# Altium schematic sheet StEth32_Base_µC.SchDoc
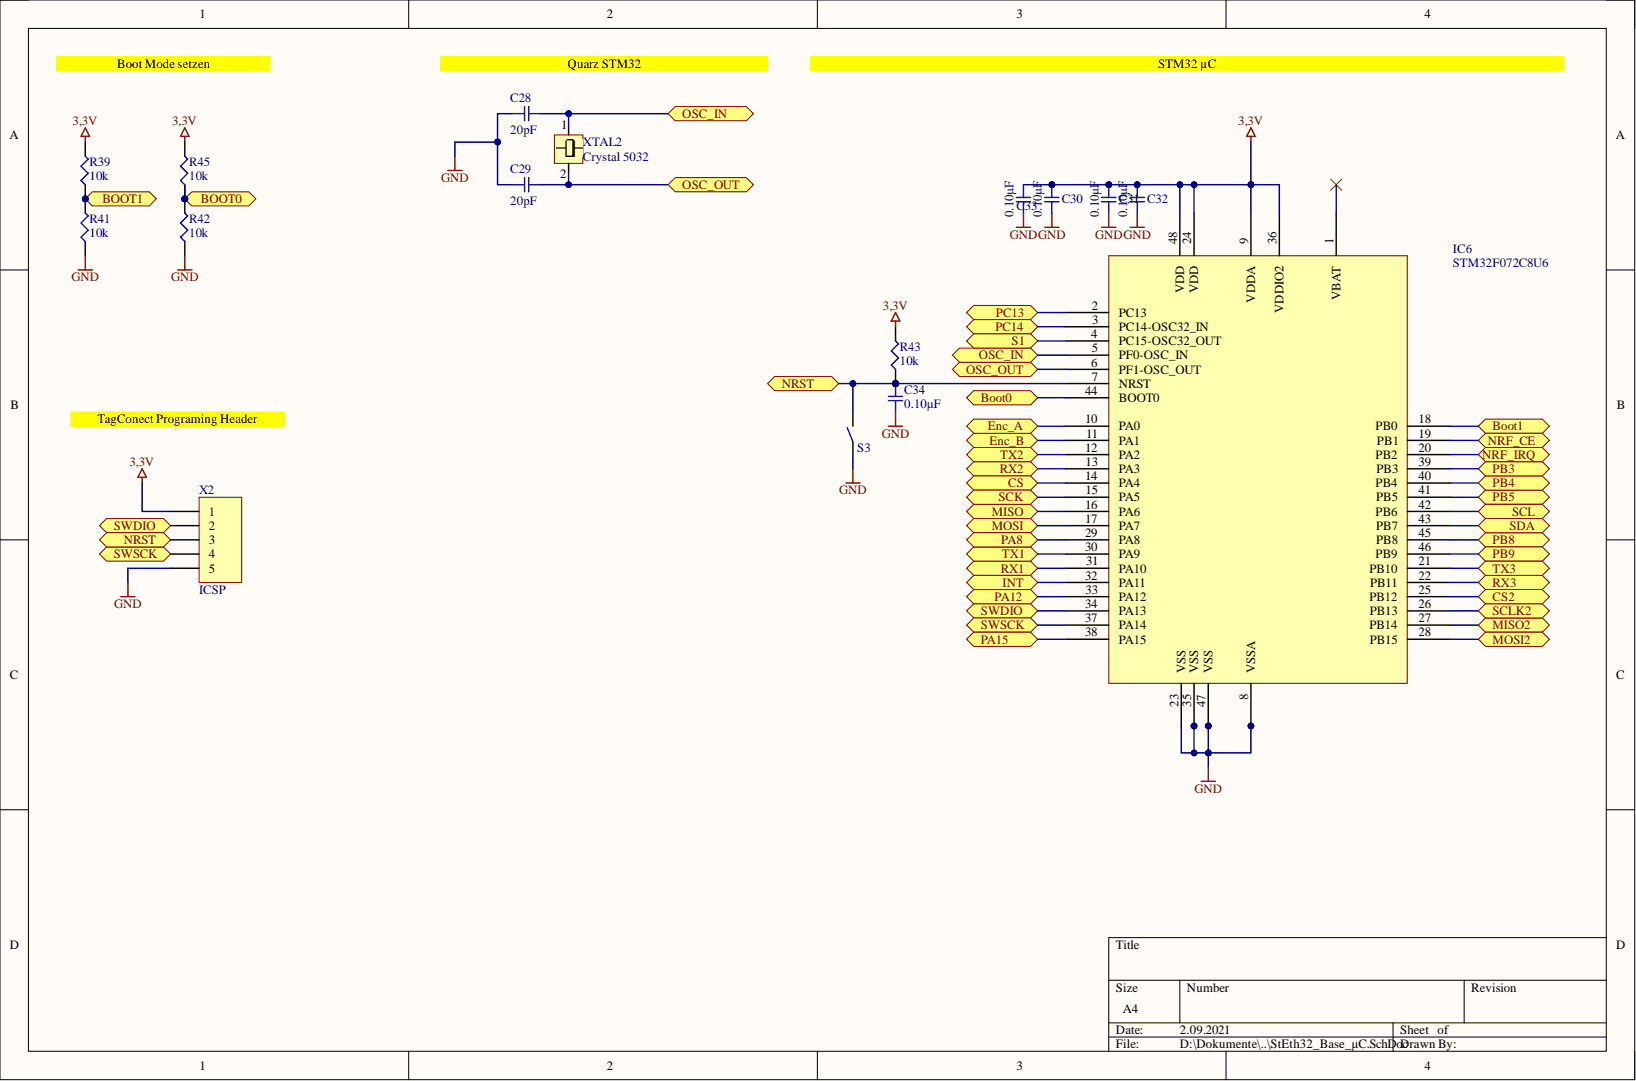
[diagram p0: StEth32_Base_µC.SchDoc]

## Parts
C28  20pF  [SMD-0603C]
C29  20pF  [SMD-0603C]
C30  0.10µF  [SMD-0603C]
C31  0.10µF  [SMD-0603C]
C32  0.10µF  [SMD-0603C]
C33  0.10µF  [SMD-0603C]
C34  0.10µF  [SMD-0603C]
IC6  STM32F072C8U6  [LQFP-48]
R39  10k  [SMD-0603-RES]
R41  10k  [SMD-0603-RES]
R42  10k  [SMD-0603-RES]
R43  10k  [SMD-0603-RES]
R45  10k  [SMD-0603-RES]
S3  Button  [Button5050]  (value not drawn)
X2  ICSP  [TC2030-MCP-NL]
XTAL2  Crystal 5032  [5032]

## Ports
BOOT0, Boot0, Boot1, BOOT1, CS, CS2, Enc_A, Enc_B, INT, MISO, MISO2, MOSI, MOSI2, NRF_CE, NRF_IRQ, NRST, OSC_IN, OSC_OUT, PA8, PA12, PA15, PB3, PB4, PB5, PB8, PB9, PC13, PC14, RX1, RX2, RX3, S1, SCK, SCL, SCLK2, SDA, SWDIO, SWSCK, TX1, TX2, TX3

## Nets
3,3V: C30.1 C31.1 C32.1 C33.1 IC6.9(VDDA) IC6.24(VDD) IC6.36(VDDIO2) IC6.48(VDD) R39.2 R43.2 R45.2 X2.1
GND: C28.1 C29.1 C30.2 C31.2 C32.2 C33.2 C34.2 IC6.8(VSSA) IC6.23(VSS) IC6.35(VSS) IC6.47(VSS) R41.1 R42.1 S3.1 X2.5
BOOT0: IC6.44(BOOT0) R42.2 R45.1 ; port BOOT0, port Boot0
BOOT1: IC6.18(PB0) R39.1 R41.2 ; port BOOT1, port Boot1
CS: IC6.14(PA4) ; port CS
CS2: IC6.25(PB12) ; port CS2
Enc_A: IC6.10(PA0) ; port Enc_A
Enc_B: IC6.11(PA1) ; port Enc_B
INT: IC6.32(PA11) ; port INT
MISO: IC6.16(PA6) ; port MISO
MISO2: IC6.27(PB14) ; port MISO2
MOSI: IC6.17(PA7) ; port MOSI
MOSI2: IC6.28(PB15) ; port MOSI2
NRF_CE: IC6.19(PB1) ; port NRF_CE
NRF_IRQ: IC6.20(PB2) ; port NRF_IRQ
NRST: C34.1 IC6.7(NRST) R43.1 S3.2 X2.3 ; port NRST
OSC_IN: C28.2 IC6.5(PF0-OSC_IN) XTAL2.1 ; port OSC_IN
OSC_OUT: C29.2 IC6.6(PF1-OSC_OUT) XTAL2.2 ; port OSC_OUT
PA8: IC6.29(PA8) ; port PA8
PA12: IC6.33(PA12) ; port PA12
PA15: IC6.38(PA15) ; port PA15
PB3: IC6.39(PB3) ; port PB3
PB4: IC6.40(PB4) ; port PB4
PB5: IC6.41(PB5) ; port PB5
PB8: IC6.45(PB8) ; port PB8
PB9: IC6.46(PB9) ; port PB9
PC13: IC6.2(PC13) ; port PC13
PC14: IC6.3(PC14-OSC32_IN) ; port PC14
RX1: IC6.31(PA10) ; port RX1
RX2: IC6.13(PA3) ; port RX2
RX3: IC6.22(PB11) ; port RX3
S1: IC6.4(PC15-OSC32_OUT) ; port S1
SCK: IC6.15(PA5) ; port SCK
SCL: IC6.42(PB6) ; port SCL
SCLK2: IC6.26(PB13) ; port SCLK2
SDA: IC6.43(PB7) ; port SDA
SWDIO: IC6.34(PA13) X2.2 ; port SWDIO
SWSCK: IC6.37(PA14) X2.4 ; port SWSCK
TX1: IC6.30(PA9) ; port TX1
TX2: IC6.12(PA2) ; port TX2
TX3: IC6.21(PB10) ; port TX3

Not connected: IC6 1(VBAT)

## Library data of the parts
C28-C34 = GCM188R71C104KA37J: CAP CER 0.1UF 16V X7R 0603; Manufacturer: Murata Electronics North America; @ qty: 0; Minimum Quantity: 1; Tolerance: ±10%; Unit Price (USD): 0.18; Applications: Automotive; Component Kind: Standard; Datasheets: http://www.murata.com/~/media/webrenewal/support/library/catalog/products/capacitor/mlcc/c03e.ashx; Digi-Key Part Number: 490-8015-1-ND; Factory Stock: 0; Features: -; Height - Seated (Max): -; Image: //media.digikey.com/Renders/Murata%20Renders/0603%28GCM188%29.jpg; Lead Spacing: -; Lead Style: -; Library Name: capacitor_murata_0603.IntLib; Mounting Type: Surface Mount, MLCC; Operating Temperature: -55°C ~ 125°C; Package / Case: 0603 (1608 Metric); Packaging: Cut Tape (CT); Part Number: GCM188R71C104KA37J; Part Status: Active; Quantity Available: 17844; Ratings: AEC-Q200; Series: GCM; Size / Dimension: 0.063" L x 0.031" W (1.60mm x 0.80mm); Temperature Coefficient: X7R; Thickness (Max): 0.035" (0.90mm); Voltage: 16V
  C28 C29: Value: 20pF
  C30-C34: Value: 0.10µF
R39 R41-R43 R45 = ERJ-3EKF1002V: RES SMD 10K OHM 1% 1/10W 0603; Manufacturer: Panasonic Electronic Components; @ qty: 1500; Factory Stock: 0; Minimum Quantity: 1; Power (Watts): 0.1W, 1/10W; Temperature Coefficient: ±100ppm/°C; Unit Price (USD): 0.00379; Component Kind: Standard; Composition: Thick Film; Datasheets: http://industrial.panasonic.com/www-cgi/jvcr13pz.cgi?E+PZ+3+AOA0002+ERJ3EKF1002V+7+WW; Digi-Key Part Number: P10.0KHCT-ND; Failure Rate: -; Features: Automotive AEC-Q200; Height - Seated (Max): 0.022" (0.55mm); Image: //media.digikey.com/Photos/Panasonic%20Photos/MFG_ERJ-P6W%20Series.jpg; Library Name: Panasonic_ERJ_0603.IntLib; Number of Terminations: 2; Operating Temperature: -55°C ~ 155°C; Package / Case: 0603 (1608 Metric); Packaging: Cut Tape (CT); Part Number: ERJ-3EKF1002V; Part Status: Active; Quantity Available: 6703141; Series: ERJ; Size / Dimension: 0.063" L x 0.031" W (1.60mm x 0.80mm); Supplier Device Package: 0603; Tolerance: ±1%; Value: 10k
S3 = Button: 6.1x3.7x2.5mm; Component Kind: Standard; Library Name: MyLibs.SchLib
XTAL2 = 5032: Component Kind: Standard; Library Name: MyLibs.SchLib
IC6 = STM32F072C8U6: Component Kind: Standard; Library Name: MyLibs.SchLib
X2 = ICSP: Component Kind: Standard; Library Name: TC2030.IntLib
